# Altium schematic sheet Multi_Power_6V3.SchDoc
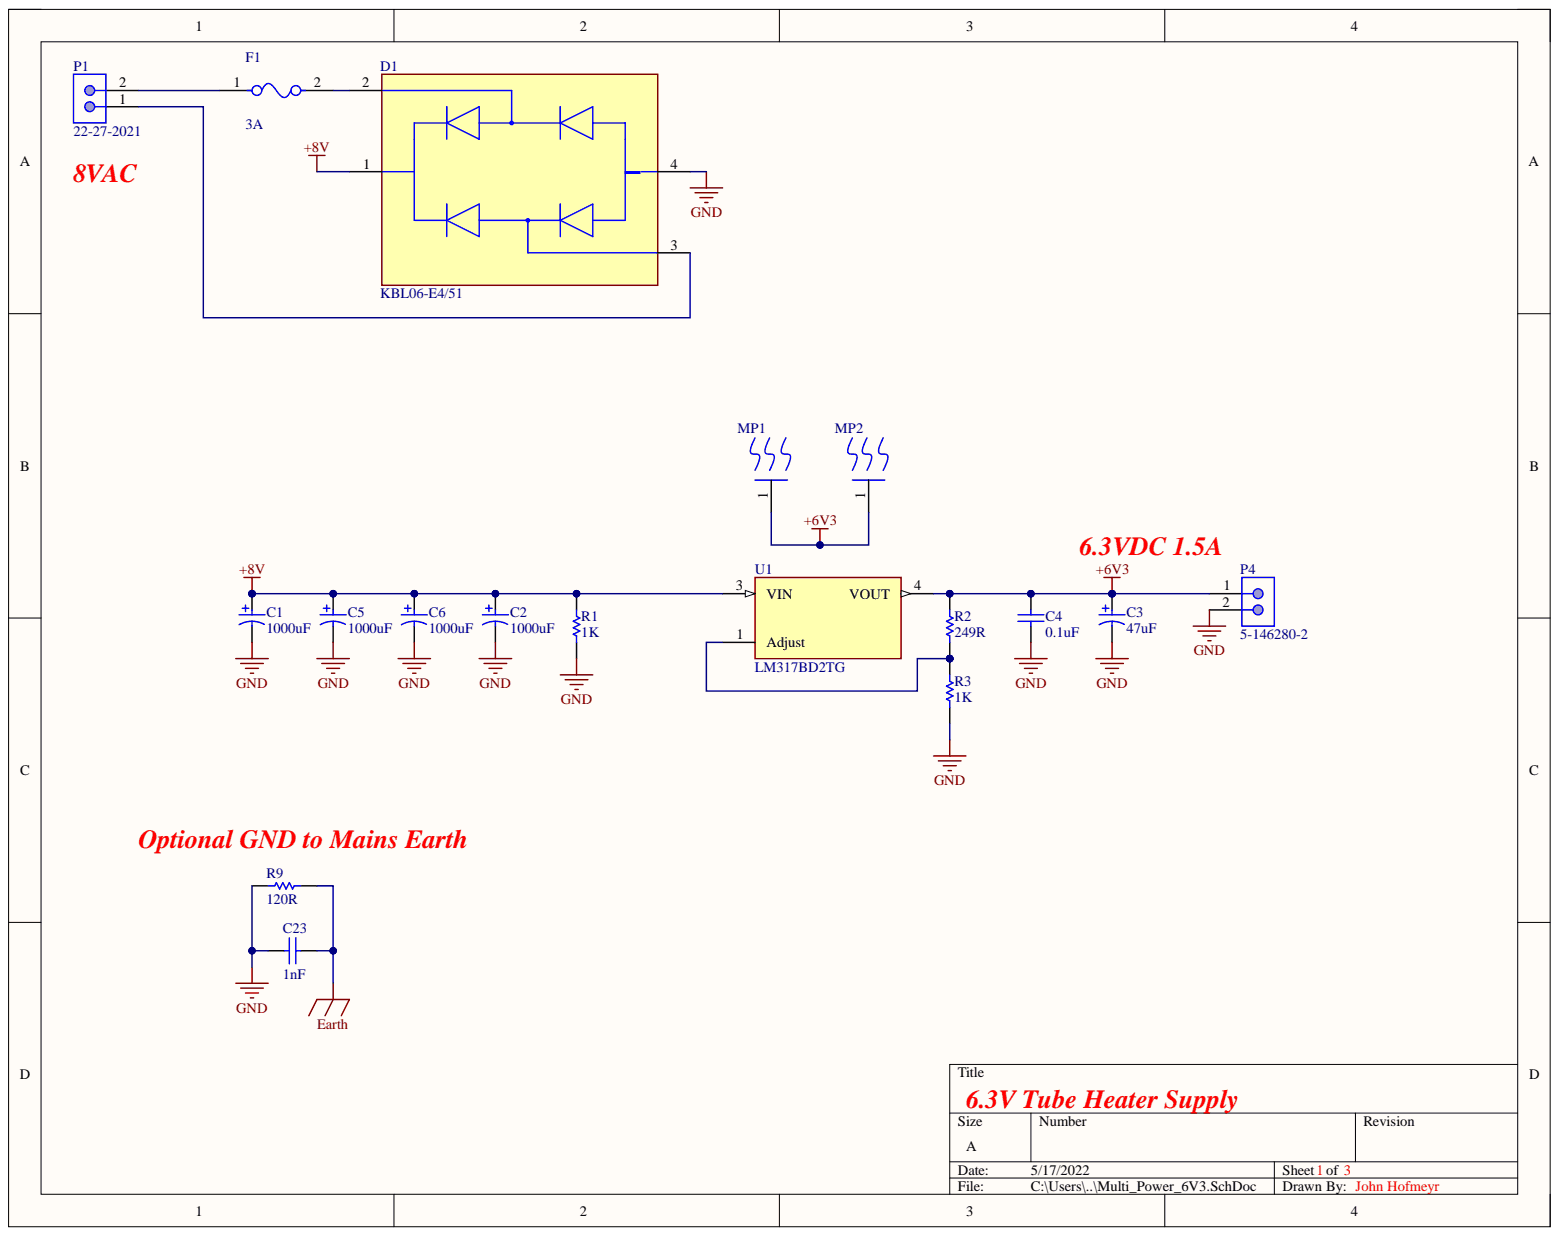
[diagram p0: Multi_Power_6V3.SchDoc]

## Parts
C1  1000uF  [PNSC-EEUFM1H221-2]
C2  1000uF  [PNSC-EEUFM1H221-2]
C3  47uF  [NIC-RNU-10x12.5-2_V]
C4  0.1uF  [CAPC2013X135X45ML10T25]
C5  1000uF  [PNSC-EEUFM1H221-2]
C6  1000uF  [PNSC-EEUFM1H221-2]
C23  1nF  [CAPC2013X94X50ML20T25]
D1  KBL06-E4/51  [FP-KBL-MFG]
F1  3A  [FP-0466-MFG]
MP1  573100D00010G  [FP-573100D00010G-MFG]  (value not drawn)
MP2  573100D00010G  [FP-573100D00010G-MFG]  (value not drawn)
P1  22-27-2021  [MOLX-22-27-2021_V]
P4  5-146280-2  [TECO-5-146280-2_V]
R1  1K  [RESC2013X50X40LL20T20]
R2  249R  [RESC2013X50X40NL20T20]
R3  1K  [RESC2013X50X40LL20T20]
R9  120R  [RESC2013X50X40LL20T20]
U1  LM317BD2TG  [FP-936-03-MFG]

## Nets
+6V3: C3.1 C4.2 MP1.1 MP2.1 P4.1 R2.2 U1.4
+8V: C1.1 C2.1 C5.1 C6.1 D1.1 R1.2 U1.3
Earth: C23.2 R9.1
GND: C1.2 C2.2 C3.2 C4.1 C5.2 C6.2 C23.1 D1.4 P4.2 R1.1 R3.1 R9.2
NetD1_2: D1.2 F1.2
NetD1_3: D1.3 P1.1
NetF1_1: F1.1 P1.2
NetR2_1: R2.1 R3.2 U1.1

## Library data of the parts
C1 C2 C5 C6 = CMP-2000-05313-1: Aluminum Electrolytic Capacitor, 1000 uF, +/- 20%, 16 V, -40 to 105 degC, 2-Pin THD, RoHS, Tape and Reel; Manufacturer: Panasonic; Capacitance: 1000µF; Impedance: 0.028O; Lifetime@Temperature: 8000Hrs@105degC; Rated Voltage: 16V; Ripple Current: 1790mA; Type: Radial; Case Size/Dimension: Ø10x16mm; Component Kind: Standard; Datasheet URL: http://www.produktinfo.conrad.com/datenblaetter/425000-449999/446746-da-01-en-ALU_KONDENSATOR_FR_R_1000_UF_16V.pdf; Datasheet Version: Nov-11; Library Name: Altium Content Vault; Manufacturer URL: http://www.panasonic.com/; Operating Temp Range: -40to+105degC; Operating Temp-Max: 105degC; Series: FR
C3 = CMP-1236-00001-1: Aluminum Electrolytic Capacitor, 47 uF +/-20%, 50 V, -55 to 105 degC, 2-Pin THD, RoHS; Manufacturer: Nichicon; PartNumber: RNU1H470MDN1PH; Leakage Current: 0.47 mA; Rated Ripple: 2700 mA; Rated Voltage: 50 V; Capacitance Tolerance: +/-20%; Component Kind: Standard; ComponentLink1Description: Manufacturer URL; ComponentLink1URL: http://www.nichicon.co.jp/; ComponentLink2Description: Datasheet; ComponentLink2URL: http://www.nichicon.co.jp/english/products/pdfs/e-f_nu.pdf; ComponentLink3Description: Package Specification; ComponentLink3URL: http://www.nichicon.co.jp/english/products/pdf/2013fpcap_catalog_nu.pdf; DatasheetVersion: Sep-13; Library Name: Altium Content Vault; Mounting Technology: Through Hole; PackageDescription: 2-Pin Through Hole Device, Dia 10 mm, Body Length 12.5 mm, Pin Spacing 5 mm; Rated Capacitance: 47 uF; RoHS: TRUE; Temperature Range: -55 to 105 degC
C4 = CMP-2100-03644-1: Chip Capacitor, 0.1 uF, +/- 10%, 50 V, -55 to 125 degC, 0805 (2012 Metric), RoHS, Tape and Reel; Manufacturer: Murata; Capacitance: 100nF; Dielectric: X7R; Dielectric Material: Ceramic; Insulation Resistance: 10GR; Voltage: 50V; Case Code (Imperial): 0805; Case Code (Metric): 2012; Case/Package: 0805; Component Kind: Standard; Datasheet URL: http://psearch.en.murata.com/capacitor/product/GRM21BR71H104KA01%23.pdf; Datasheet Version: 42675.0; Depth: 1.25mm; Height: 1.25mm; Lead Free: LeadFree; Length: 2mm; Library Name: Altium Content Vault; Manufacturer URL: http://www.murata.com/; Material: Ceramic; Max Operating Temperature: 125degC; Min Operating Temperature: -55degC; Mount: SurfaceMount; Number of Pins: 2; Package Description: 2-Pin Surface Mount Device, Body 2 x 1.25 mm; Package Quantity: 1; Package Reference: 0805; Packaging: TapeandReel; Radiation Hardening: No; REACH SVHC: Unknown; RoHSCompliant: true; Termination: SMD/SMT; Thickness: 0.053inch; Tolerance: 10%; Voltage Rating: 50V; Voltage Rating (DC): 50V; Width: 0.049inch
C23 = CMP-1036-02979-2: Manufacturer: AVX Interconnect / Elco; Part Number: 08055C102KAT2A.; Component Kind: Standard; Library Name: Altium Content Vault
D1 = CMP-02540-000012-1: BRIDGE RECT 1PHASE 600V 4A KBL; Manufacturer: Vishay; Max Junction Temp: +150°C; Max Surge Forward Current: 200A; Peak Reverse Current: 5uA; Standoff Height: 0.2mm; Automotive: No; Category: Diode; Component Kind: Standard; ComponentLink2Description: Datasheet; ComponentLink2URL: http://www.vishay.com/docs/88655/kbl005.pdf; Device Class L1: Discrete Semiconductors; Device Class L2: Diodes; Device Class L3: Rectifier Diodes; Digikey Description: BRIDGE RECT 1PHASE 600V 4A KBL; Forward Current: 4A; Height: 16.3mm; Lead Free: Yes; Library Name: Altium Content Vault; Manufacturer Part Number: KBL06-E4/51; Max Repetitive Reverse Voltage: 600V; Mouser Description: Bridge Rectifiers 4.0 Amp 600 Volt; Octopart: https://octopart.com/kbl06-e4%2F51-vishay-42174180; Package: KBL; Reverse Voltage: 600V; RoHS: TRUE; Temperature: -50°C to +150°C; Temperature Range High: +150°C; Temperature Range Low: -50°C
F1 = CMP-08605-000054-1: FUSE BOARD MNT 3A 32VAC/VDC 1206; Manufacturer: Littelfuse; Manufacturer Part Number: 0466003.NR; Fuse Blow Speed: Fast; Fuse I2T: 0.576A2Sec; Fuse Interrupt Ac Current: 50A; Fuse Voltage Drop: 75.99mV; Max Fuse Ac Voltage: 32V; Resistance: 0.019Ω; Value: 0.019Ω; Automotive: No; Category: Fuse; Component Kind: Standard; ComponentLink2Description: Datasheet; ComponentLink2URL: https://www.littelfuse.com/~/media/electronics/datasheets/fuses/littelfuse_fuse_466_datasheet.pdf.pdf; Device Class L1: Circuit Protection; Device Class L2: Fuses; Device Class L3: unset; Digikey Description: FUSE BOARD MNT 3A 32VAC/VDC 1206; Fuse Current: 3A; Fuse Interrupt Dc Current: 50A; Height: 0.66mm; Lead Free: Yes; Library Name: Altium Content Vault; Max Fuse Dc Voltage: 32V; Mouser Description: Surface Mount Fuses 32V 3A VFA Slimline; Octopart: https://octopart.com/0466003.nr-littelfuse-565175; Package: 0466; RoHS: TRUE; Temperature: -55°C to +90°C; Temperature Range High: +90°C; Temperature Range Low: -55°C; Voltage Rating: 32V
MP1 MP2 = CMP-69313-000002-1: HEATSINK SMT D-PAK/TO-252 TIN; Manufacturer: Aavid Thermalloy; Mating Package: D‐Pak; Automotive: No; Category: UNK; Component Kind: Standard; ComponentLink2Description: Datasheet; ComponentLink2URL: https://www.shopaavid.com/images/Product/images/Board%20Level%20Cooling-Surface%20Mount%205731%20v1.pdf; Device Class L1: Electromechanical; Device Class L2: Thermal Management; Device Class L3: Heat Sinks; Digikey Description: HEATSINK SMT D-PAK/TO-252 TIN; Height: 10.16mm; Lead Free: Yes; Library Name: Altium Content Vault; Manufacturer Part Number: 573100D00010G; Mouser Description: Heat Sinks SLV HEATSINK TO-252; Octopart: https://octopart.com/573100d00010g-aavid+thermalloy-807578; Package: 573100D00010G; RoHS: TRUE
P1 = CMP-2000-05537-1: Male Header, Pitch 2.54 mm, 1 x 2 Position, Height 11.7 mm, Tail Length 3.56 mm, 0 to 75 degC, RoHS, Bulk; Manufacturer: Molex; PartNumber: 0022272021; Current - Max: 4A; No. of Positions: 2; No. of Rows: 1; Package Version: Rev. BC, 02/2012; Pitch: 2.54mm; Voltage - Max: 250V; Component Kind: Standard; Contact material: Tinplatedbrass; Current rating: 4A; Datasheet URL: http://www.molex.com/pdm_docs/sd/022272021_sd.pdf; Datasheet Version: Rev. F, 06/2009; Housing material: Nylon(UL94V-0); Library Name: Altium Content Vault; Manufacturer URL: http://www.molex.com/; Mounting Technology: ThroughHole; Operating temperature: 0°Cto+75°C; Orientation: Vertical; Package Description: 2-Leads Header, Body 5.08 x 5.8 mm, Pitch 2.54 mm; Package Reference: 22-27-2021; Voltage rating: 250V
P4 = CMP-2000-07492-1: Male Header, Pitch 2.54 mm, 1 x 2 Position, Height 8.21 mm, Tail Length 3.05 mm, -65 to 105 degC, RoHS, Bulk; Manufacturer: TE Connectivity; Approvals: CSA; Board Standoff: No(Without); Case\/Package: 100; Current Rating: 3A; High Speed Serial Data Connector: No; High Temperature Housing: Yes; Mating Post Length: 0.23inch; Max Current Rating: 5A; Number of Contacts: 2; PCB Mount Retention: Without; PCB Mounting Orientation: Vertical; PCB Thickness: 0.063inch; Pitch: 2.54mm; Colour: Black; Component Kind: Standard; Connector Type: Header; Contact Gender: Male; Contact Material: CopperAlloy; Contact Mating Length: 0.23inch; Contact Plating: Matte; Contact Protection: No(Without); Contact Shape: Square; Datasheet URL: http://www.te.com/commerce/DocumentDelivery/DDEController?Action=showdoc&DocId=Customer+Drawing%7F146280%7FH1%7Fpdf%7FEnglish%7FENG_CD_146280_H1.pdf%7F5-146280-2; Datasheet Version: Rev. H1, 08/2016; Depth: 2.34mm; Flammability Rating: UL94V-0; Gasket: No(Without); Housing Colour: Black; Housing Material: Polymer; Keyed: No; Length: 4.67mm; Library Name: Altium Content Vault; Manufacturer URL: http://www.te.com/; Mating Alignment: No(Without); Mating Connector Lock: No(Without); Max Operating Temperature: 105degC; Min Operating Temperature: -65degC; Mount: ThroughHole; Mounting Technology: ThroughHole; Number of Positions: 2; Number of Rows: 1; Orientation: Straight; Package Description: Male Header, Pitch 2.54 mm, 1 x 2 Position, Height 8.21 mm, Tail Length 3.05 mm; Package Quantity: 500; Package Reference: 5-146280-2; Packaging: Carton; Panel Mount Retention: No(Without); Radiation Hardening: No; RoHSCompliant: true; Row Spacing: 2.54mm; Selectively Loaded: No(Without); Stabilizers: No(Without); Termination: ThroughHole; Termination Post Length: 0.12inch
R1 R3 = CMP-2001-03210-1: Manufacturer: Susumu; Part Number: RR1220P-102-D; Component Kind: Standard; Library Name: Altium Content Vault
R2 = CMP-2001-03342-1: Manufacturer: Susumu; Part Number: RR1220P-2490-D-M; Component Kind: Standard; Library Name: Altium Content Vault
R9 = CMP-2001-03236-1: Manufacturer: Susumu; Part Number: RR1220P-121-D; Component Kind: Standard; Library Name: Altium Content Vault
U1 = CMP-07128-000010-1: IC REG LINEAR POS ADJ 1.5A D2PAK; Manufacturer: ON Semiconductor; Max Junction Temp: +125°C; Max Supply Voltage: 40V; Number Of Outputs: 1; Output Type: AdjustableProgrammable; Output Voltage: 1.2-37V; Standoff Height: 0mm; Automotive: No; Category: IC; Component Kind: Standard; ComponentLink2Description: Datasheet; ComponentLink2URL: https://www.onsemi.com/pub/Collateral/LM317-D.PDF; Device Class L1: Integrated Circuits (ICs); Device Class L2: Power Management ICs; Device Class L3: Voltage Regulators - Linear; Digikey Description: IC REG LINEAR POS ADJ 1.5A D2PAK; Footprint Url: https://www.onsemi.com/pub/Collateral/936-03.PDF; Height: 4.826mm; Lead Free: Yes; Library Name: Altium Content Vault; Manufacturer Part Number: LM317BD2TG; Mouser Description: Linear Voltage Regulators 1.5A ADJ 1.2-37V Positive; Octopart: https://octopart.com/lm317bd2tg-on+semiconductor-1010532; Output Current: 1.5A; Package: 936-03; RoHS: TRUE; Temperature: -40°C to +125°C; Temperature Range High: +125°C; Temperature Range Low: -40°C
